# Altium schematic sheet TT_C_Interface.SchDoc
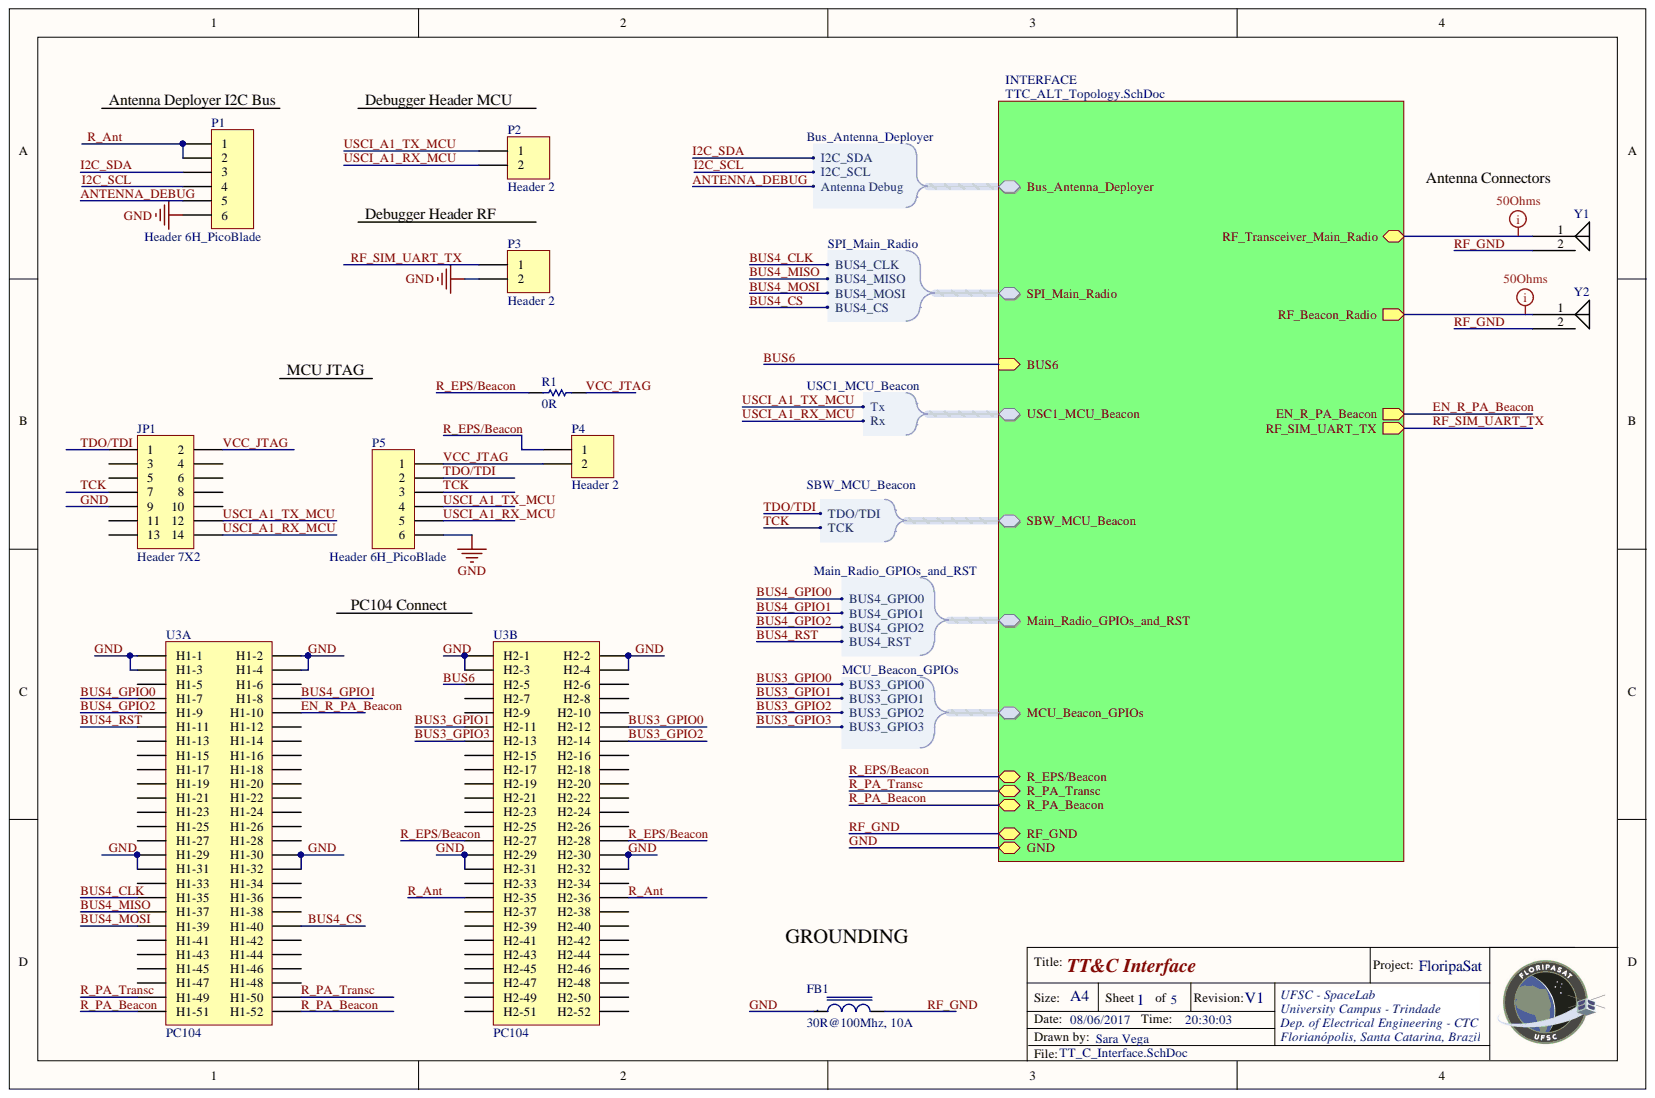
[diagram p0: TT_C_Interface.SchDoc]

## Parts
FB1  30R@100Mhz, 10A  [IND1210]
JP1  Header 7X2  [HDR2X7]
P1  Header 6H_PicoBlade  [HDR6 PicoBlade]
P2  Header 2  [HDR 1X2]
P3  Header 2  [HDR 1X2]
P4  Header 2  [HDR 1X2]
P5  Header 6H_PicoBlade  [HDR6 PicoBlade]
R1  0R  [1206]
U3  PC104  [PC104]  (parts A,B)
Y1  Coaxial_Connectors_90º  [CONN MCX JACK  R/A  50 OHM SMD - duplicate]  (value not drawn)
Y2  Coaxial_Connectors_90º  [CONN MCX JACK  R/A  50 OHM SMD - duplicate]  (value not drawn)

## Nets
ANTENNA_DEBUG: P1.5
BUS3_GPIO0: U3.H2-12
BUS3_GPIO1: U3.H2-11
BUS3_GPIO2: U3.H2-14
BUS3_GPIO3: U3.H2-13
BUS4_CLK: U3.H1-35
BUS4_CS: U3.H1-40
BUS4_GPIO0: U3.H1-7
BUS4_GPIO1: U3.H1-8
BUS4_GPIO2: U3.H1-9
BUS4_MISO: U3.H1-37
BUS4_MOSI: U3.H1-39
BUS4_RST: U3.H1-11
BUS6: U3.H2-5
EN_R_PA_Beacon: U3.H1-10
GND: FB1.1 JP1.9 P1.6 P3.2 P5.6 U3.H1-1 U3.H1-2 U3.H1-3 U3.H1-4 U3.H1-29 U3.H1-30 U3.H1-31 U3.H1-32 U3.H2-1 U3.H2-2 U3.H2-3 U3.H2-4 U3.H2-29 U3.H2-30 U3.H2-31 U3.H2-32
I2C_SCL: P1.4
I2C_SDA: P1.3
R_Ant: P1.1 P1.2 U3.H2-35 U3.H2-36
R_EPS/Beacon: P4.1 R1.2 U3.H2-27 U3.H2-28
R_PA_Beacon: U3.H1-51 U3.H1-52
R_PA_Transc: U3.H1-49 U3.H1-50
RF_GND: FB1.2 Y1.2 Y2.2
RF_SIM_UART_TX: P3.1
TCK: JP1.7 P5.3
TDO/TDI: JP1.1 P5.2
USCI_A1_RX_MCU: JP1.14 P2.2 P5.5
USCI_A1_TX_MCU: JP1.12 P2.1 P5.4
VCC_JTAG: JP1.2 P4.2 P5.1 R1.1
NetJP1_3: JP1.3
NetJP1_4: JP1.4
NetJP1_5: JP1.5
NetJP1_6: JP1.6
NetJP1_8: JP1.8
NetJP1_10: JP1.10
NetJP1_11: JP1.11
NetJP1_13: JP1.13
NetU3_H1-5: U3.H1-5
NetU3_H1-6: U3.H1-6
NetU3_H1-12: U3.H1-12
NetU3_H1-13: U3.H1-13
NetU3_H1-14: U3.H1-14
NetU3_H1-15: U3.H1-15
NetU3_H1-16: U3.H1-16
NetU3_H1-17: U3.H1-17
NetU3_H1-18: U3.H1-18
NetU3_H1-19: U3.H1-19
NetU3_H1-20: U3.H1-20
NetU3_H1-21: U3.H1-21
NetU3_H1-22: U3.H1-22
NetU3_H1-23: U3.H1-23
NetU3_H1-24: U3.H1-24
NetU3_H1-25: U3.H1-25
NetU3_H1-26: U3.H1-26
NetU3_H1-27: U3.H1-27
NetU3_H1-28: U3.H1-28
NetU3_H1-33: U3.H1-33
NetU3_H1-34: U3.H1-34
NetU3_H1-36: U3.H1-36
NetU3_H1-38: U3.H1-38
NetU3_H1-41: U3.H1-41
NetU3_H1-42: U3.H1-42
NetU3_H1-43: U3.H1-43
NetU3_H1-44: U3.H1-44
NetU3_H1-45: U3.H1-45
NetU3_H1-46: U3.H1-46
NetU3_H1-47: U3.H1-47
NetU3_H1-48: U3.H1-48
NetU3_H2-6: U3.H2-6
NetU3_H2-7: U3.H2-7
NetU3_H2-8: U3.H2-8
NetU3_H2-9: U3.H2-9
NetU3_H2-10: U3.H2-10
NetU3_H2-15: U3.H2-15
NetU3_H2-16: U3.H2-16
NetU3_H2-17: U3.H2-17
NetU3_H2-18: U3.H2-18
NetU3_H2-19: U3.H2-19
NetU3_H2-20: U3.H2-20
NetU3_H2-21: U3.H2-21
NetU3_H2-22: U3.H2-22
NetU3_H2-23: U3.H2-23
NetU3_H2-24: U3.H2-24
NetU3_H2-25: U3.H2-25
NetU3_H2-26: U3.H2-26
NetU3_H2-33: U3.H2-33
NetU3_H2-34: U3.H2-34
NetU3_H2-37: U3.H2-37
NetU3_H2-38: U3.H2-38
NetU3_H2-39: U3.H2-39
NetU3_H2-40: U3.H2-40
NetU3_H2-41: U3.H2-41
NetU3_H2-42: U3.H2-42
NetU3_H2-43: U3.H2-43
NetU3_H2-44: U3.H2-44
NetU3_H2-45: U3.H2-45
NetU3_H2-46: U3.H2-46
NetU3_H2-47: U3.H2-47
NetU3_H2-48: U3.H2-48
NetU3_H2-49: U3.H2-49
NetU3_H2-50: U3.H2-50
NetU3_H2-51: U3.H2-51
NetU3_H2-52: U3.H2-52
NetY1_1: Y1.1
NetY2_1: Y2.1

## Library data of the parts
FB1 = FB_30R_10A_100MHz_1210: Ferrite Bead 30 OHM 10A 100MHz; Partnumber: BLE32PN300SN1L; Component Kind: Standard; NCM: 8504.50.00; Library Name: FloripaSatSchlib.SchLib
JP1 = Header 7X2: Header, 7-Pin, Dual row; Partnumber: 90131-0127; Component Kind: Standard; LatestRevisionDate: 17-Jul-2002; LatestRevisionNote: Re-released for DXP Platform.; Library Name: Miscellaneous Connectors.IntLib; Signal Integrity: Connector; Publisher: Altium Limited
P1 P5 = Header 6H_PicoBlade: Header, 6-Pin, Right Angle; Partnumber: 53398-0671; Component Kind: Standard; LatestRevisionDate: 17-Jul-2002; LatestRevisionNote: Re-released for DXP Platform.; Signal Integrity: Connector; Library Name: FloripaSatSchlib.SchLib; Publisher: Altium Limited
P2-P4 = Header 2: Header, 2-Pin; PartNumber: 826646-2; Component Kind: Standard; LatestRevisionDate: 17-Jul-2002; LatestRevisionNote: Re-released for DXP Platform.; Signal Integrity: Connector; Library Name: FloripaSatSchlib.SchLib; Publisher: Altium Limited
R1 = R0R_JUMPER_1/2W_1206: RES 0.0 OHM 1/2W JUMP 1206; Partnumber: CRCW12060000Z0EAHP; Component Kind: Standard; NCM: 8533.21.20; Library Name: FloripaSatSchlib.SchLib
U3 = PC104: Partnumber: ESQ-126-39-G-D; Component Kind: Standard; Description: PC104; Library Name: FloripaSatSchlib.SchLib
Y1 Y2 = Coaxial_Connectors_90º: CONN MCX JACK R/A 50OHM SMD; Partnumber: 1-1337583-0; Component Kind: Standard; Library Name: FloripaSatSchlib.SchLib
